# Altium schematic sheet Connectors.SchDoc(Connectors)
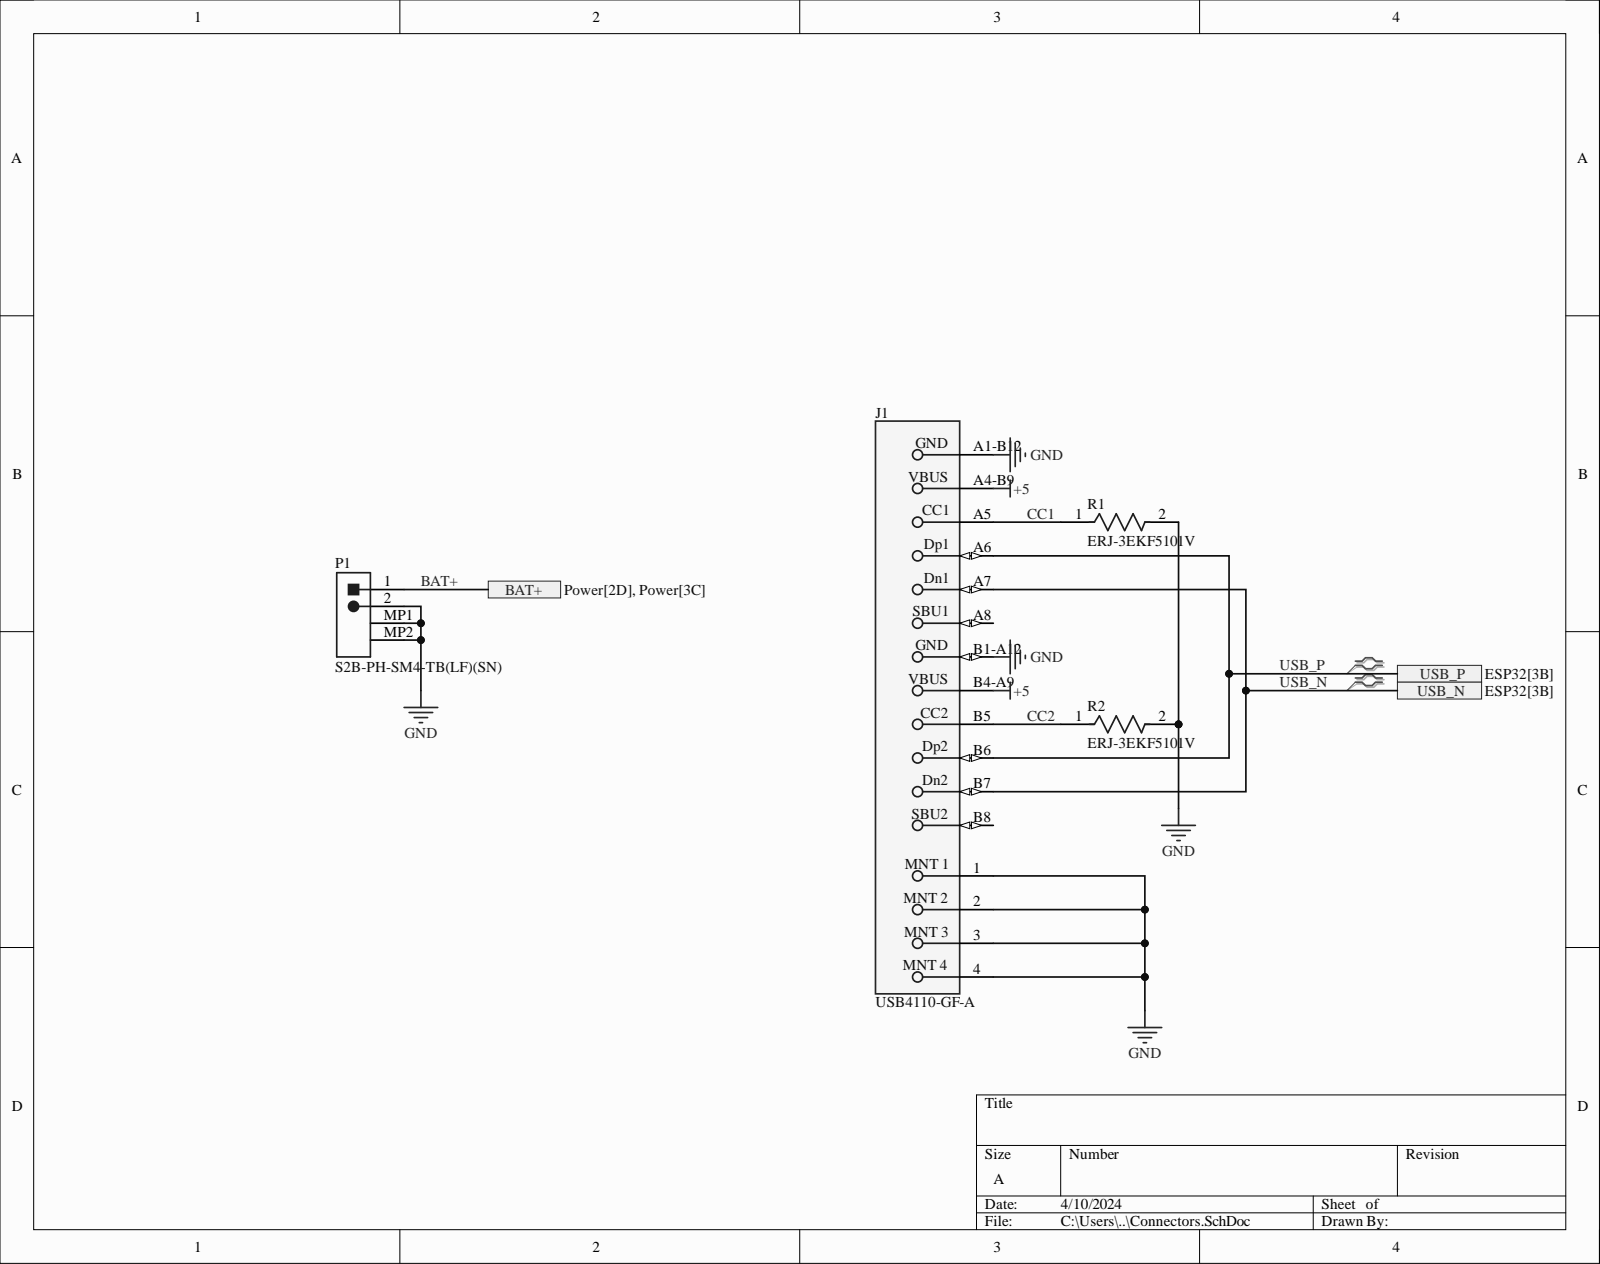
[diagram p0: Connectors.SchDoc]

## Parts
J1  USB4110-GF-A  [FP-USB4110-GF-A-MFG]
P1  S2B-PH-SM4-TB(LF)(SN)  [JST- S2B-PH-SM4-TB(LF)(SN)-2_V]
R1  ERJ-3EKF5101V  [FP-ERJ3EK-MFG]
R2  ERJ-3EKF5101V  [FP-ERJ3EK-MFG]

## Ports
BAT+, USB_N, USB_P

## Nets
+5: J1.A4-B9 J1.B4-A9
GND: J1.1 J1.2 J1.3 J1.4 J1.A1-B12 J1.B1-A12 P1.2 P1.MP1 P1.MP2 R1.2 R2.2
BAT+: P1.1 ; port BAT+
CC1: J1.A5 R1.1
CC2: J1.B5 R2.1
USB_N: J1.A7 J1.B7 ; port USB_N
USB_P: J1.A6 J1.B6 ; port USB_P

Not connected: J1 A8 B8

## Library data of the parts
J1 = CMP-245163-000002-1: CONN USB 2.0 TYPE-C R/A SMT; Manufacturer: GCT; Conn Orientation: Right Angle; Current Rating: 3/4.25/1.25/0.25A; Is Female: yes; Number Of Contacts: 16; Shielding: true; Standoff Height: 0.1mm; Usb Standard: USB 2.0; Voltage Rating: 20V; Automotive: No; Category: Conn; Component Kind: Standard; ComponentLink2Description: Datasheet; ComponentLink2URL: https://gct.co/files/drawings/usb4110.pdf; Contact Material: Gold,Nickel; Device Class L1: Connectors; Device Class L2: USB Connectors; Device Class L3: unset; Digikey Description: CONN USB 2.0 TYPE-C R/A SMT; Height: 3.46mm; Lead Free: Yes; Library Name: Altium Content Vault; Manufacturer Part Number: USB4110-GF-A; Octopart: https://octopart.com/usb4110-gf-a-gct-103941985; Package: 0; RoHS: TRUE; Temperature: -40°C to +85°C; Temperature Range High: +85°C; Temperature Range Low: -40°C
P1 = CMP-1755-00001-1: Male Header, Pitch 2 mm, 1 x 2 Position, Height 5.5 mm, -25 to 85 degC, RoHS, Tape and Reel; Current Rating: 3 A; Colour: Black; Component Kind: Standard; ComponentLink1Description: Manufacturer URL; ComponentLink1URL: http://www.jst.com/home2.html; ComponentLink2Description: Datasheet; ComponentLink2URL: http://www.jst-mfg.com/product/pdf/eng/ePH.pdf; Contact Finish: Lead; Contact Material: Bronze; Contact Plating: Tin; DatasheetVersion: Dec-12; Height: 8.13 mm; Lead Pitch: 0.1 inch; Length: 101.19 mm; Library Name: Altium Content Vault; Max Operating Temperature: 105degC; Min Operating Temperature: -65degC; Mount: Through Hole; Package Quantity: 100; PackageDescription: Male Header, Pitch 2 mm, 1 x 2 Position, Height 5.5 mm; PackageReference: S2B-PH-SM4-TB(LF)(SN)-2; Packaging: Bulk; Pins: 40; Radiation Hardening: No; RoHSCompliant: True; Width: 2.34 mm
R1 R2 = CMP-2000-00540-8: RES SMD 5.1K OHM 1% 1/10W 0603; Max Power Dissipation: 100mW; Resistance: 5.1kR; Temperature Coefficient: 100ppm/°C; Voltage Rating: 75V; Case Code (Imperial): 0603; Case Code (Metric): 1608; Case/Package: 0603; Component Kind: Standard; Construction: Rectangular; Contact Plating: Tin; Depth: 0.8mm; Features: Automotive AEC-Q200; Height: 0.022inch; Lead Free: Lead Free; Length: 1.6mm; Library Name: Altium Content Vault; Max Operating Temperature: 155°C; Military Standard: Not; Min Operating Temperature: -55°C; Mount: Surface Mount; Number of Terminations: 2; Package Quantity: 1; Packaging: Tape and Reel; Power Rating: 100mW; Radiation Hardening: No; Reach SVHC Compliant: Yes; Resistance Tolerance: 1%; Resistor Type: Thick Film; RoHS Compliant: Yes; Series: ERJ; Size Code: 0603; Termination: SMD/SMT; Tolerance: 1%; Voltage Rating (DC): 75V; Weight: 7.1E-05oz; Width: 0.8mm
